# Altium schematic sheet Sheet1.SchDoc
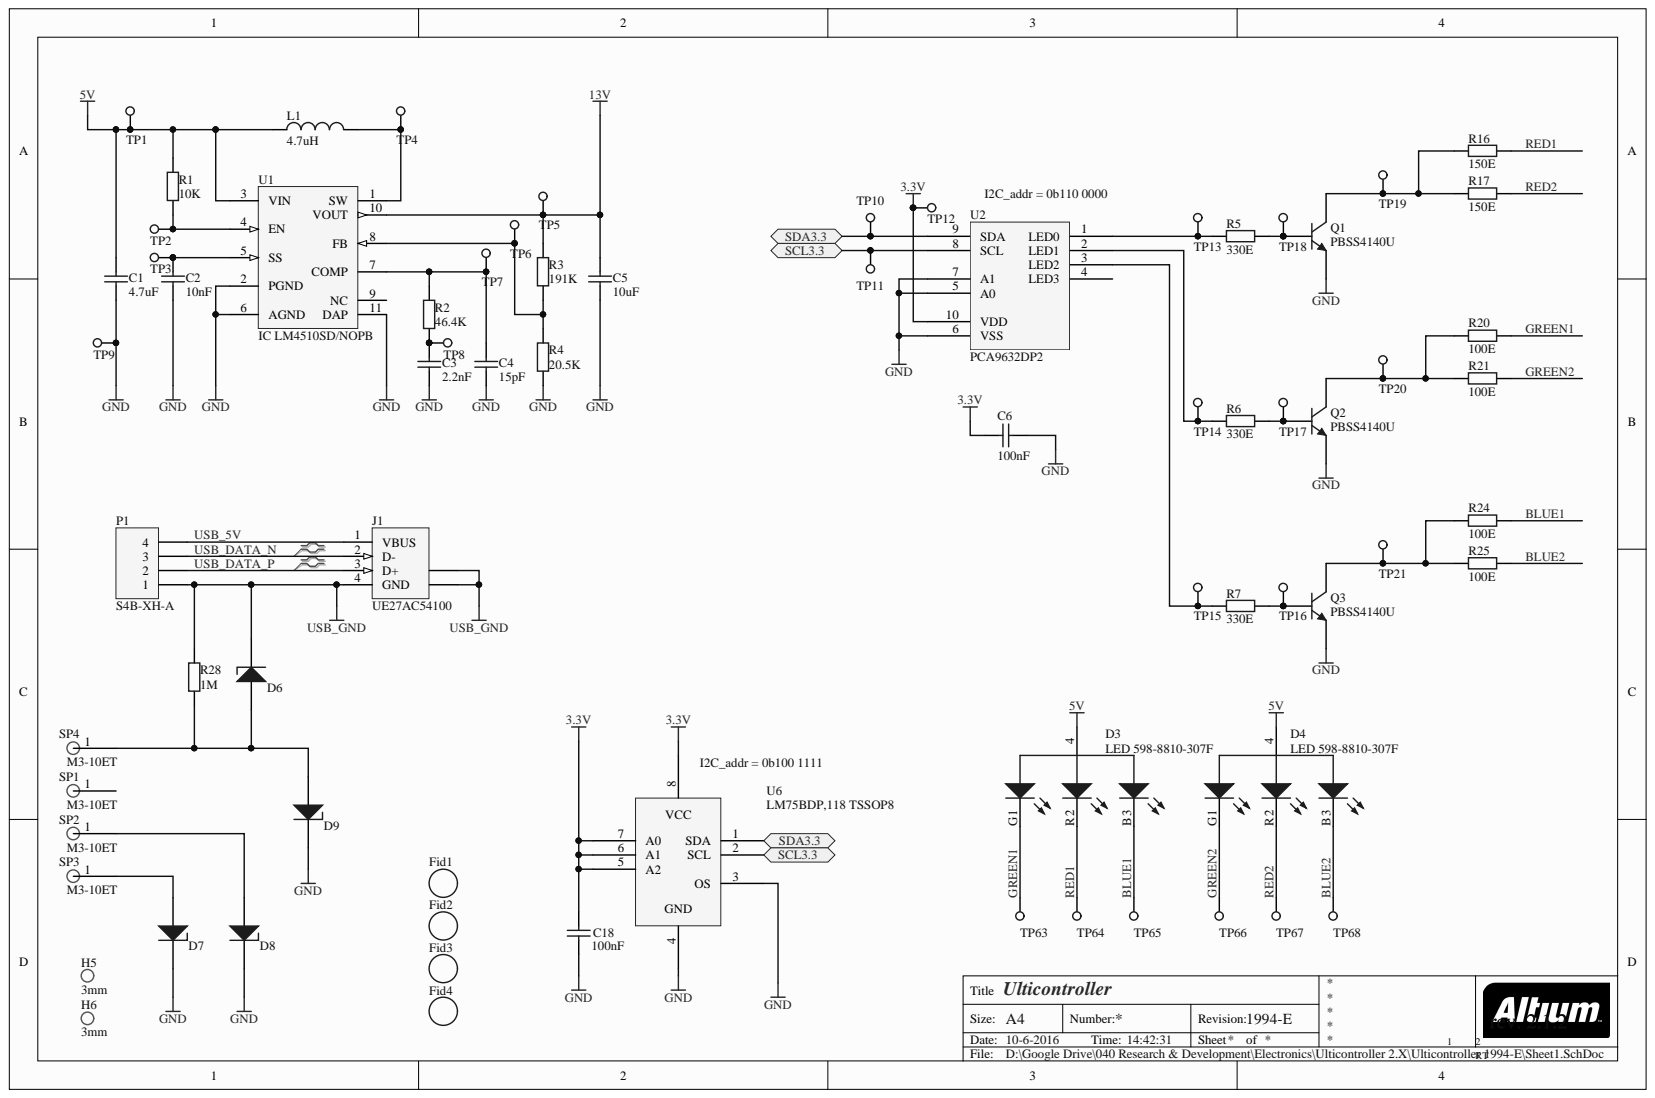
[diagram p0: Sheet1.SchDoc]

## Parts
C1  4.7uF  [C1206 (3216)]
C2  10nF  [C0805 (2012)]
C3  2.2nF  [C0805 (2012)]
C4  15pF  [C0805 (2012)]
C5  10uF  [C1206 (3216)]
C6  100nF  [C0805 (2012)]
C18  100nF  [C0805 (2012)]
D3  LED 598-8810-307F  Mfg code: 598-8810-307F  [LED SMD  598-8810-307F]
D4  LED 598-8810-307F  Mfg code: 598-8810-307F  [LED SMD  598-8810-307F]
D6  ESD9X3.3ST5G  [SODFL100X40-2N]  (value not drawn)
D7  ESD9X3.3ST5G  [SODFL100X40-2N]  (value not drawn)
D8  ESD9X3.3ST5G  [SODFL100X40-2N]  (value not drawn)
D9  ESD9X3.3ST5G  [SODFL100X40-2N]  (value not drawn)
Fid1  Fiducial  [Fiducial 1,5mm]  (value not drawn)  (no BOM)
Fid2  Fiducial  [Fiducial 1,5mm]  (value not drawn)  (no BOM)
Fid3  Fiducial  [Fiducial 1,5mm]  (value not drawn)  (no BOM)
Fid4  Fiducial  [Fiducial 1,5mm]  (value not drawn)  (no BOM)
H5  3mm  [Mounting Hole 3mm PTH]  (no BOM)
H6  3mm  [Mounting Hole 3mm PTH]  (no BOM)
J1  UE27AC54100  [USB A]
L1  4.7uH  [Inductor MCSDC0604]
P1  S4B-XH-A  [S4B-XH-A]
Q1  PBSS4140U  [IC SOT323]
Q2  PBSS4140U  [IC SOT323]
Q3  PBSS4140U  [IC SOT323]
R1  10K  [R0805 (2012)]
R2  46.4K  [R0805 (2012)]
R3  191K  [R0805 (2012)]
R4  20.5K  [R0805 (2012)]
R5  330E  [R0805 (2012)]
R6  330E  [R0805 (2012)]
R7  330E  [R0805 (2012)]
R16  150E  [R0805 (2012)]
R17  150E  [R0805 (2012)]
R20  100E  [R0805 (2012)]
R21  100E  [R0805 (2012)]
R24  100E  [R0805 (2012)]
R25  100E  [R0805 (2012)]
R28  1M  [R0805 (2012)]
SP1  M3-10ET  [SPACER M3-10ET]
SP2  M3-10ET  [SPACER M3-10ET]
SP3  M3-10ET  [SPACER M3-10ET]
SP4  M3-10ET  [SPACER M3-10ET]
TP1  2mm  [TESTPOINT 2mm]  (value not drawn)  (no BOM)
TP2  2mm  [TESTPOINT 2mm]  (value not drawn)  (no BOM)
TP3  2mm  [TESTPOINT 2mm]  (value not drawn)  (no BOM)
TP4  2mm  [TESTPOINT 2mm]  (value not drawn)  (no BOM)
TP5  2mm  [TESTPOINT 2mm]  (value not drawn)  (no BOM)
TP6  2mm  [TESTPOINT 2mm]  (value not drawn)  (no BOM)
TP7  2mm  [TESTPOINT 2mm]  (value not drawn)  (no BOM)
TP8  2mm  [TESTPOINT 2mm]  (value not drawn)  (no BOM)
TP9  2mm  [TESTPOINT 2mm]  (value not drawn)  (no BOM)
TP10  2mm  [TESTPOINT 2mm]  (value not drawn)  (no BOM)
TP11  2mm  [TESTPOINT 2mm]  (value not drawn)  (no BOM)
TP12  2mm  [TESTPOINT 2mm]  (value not drawn)  (no BOM)
TP13  2mm  [TESTPOINT 2mm]  (value not drawn)  (no BOM)
TP14  2mm  [TESTPOINT 2mm]  (value not drawn)  (no BOM)
TP15  2mm  [TESTPOINT 2mm]  (value not drawn)  (no BOM)
TP16  2mm  [TESTPOINT 2mm]  (value not drawn)  (no BOM)
TP17  2mm  [TESTPOINT 2mm]  (value not drawn)  (no BOM)
TP18  2mm  [TESTPOINT 2mm]  (value not drawn)  (no BOM)
TP19  2mm  [TESTPOINT 2mm]  (value not drawn)  (no BOM)
TP20  2mm  [TESTPOINT 2mm]  (value not drawn)  (no BOM)
TP21  2mm  [TESTPOINT 2mm]  (value not drawn)  (no BOM)
TP63  2mm  [TESTPOINT 2mm]  (value not drawn)  (no BOM)
TP64  2mm  [TESTPOINT 2mm]  (value not drawn)  (no BOM)
TP65  2mm  [TESTPOINT 2mm]  (value not drawn)  (no BOM)
TP66  2mm  [TESTPOINT 2mm]  (value not drawn)  (no BOM)
TP67  2mm  [TESTPOINT 2mm]  (value not drawn)  (no BOM)
TP68  2mm  [TESTPOINT 2mm]  (value not drawn)  (no BOM)
U1  IC LM4510SD/NOPB  Mfg code: LM4510SD/NOPB  [IC SDA10A]
U2  PCA9632DP2  [IC TSSOP-10]
U6  LM75BDP,118 TSSOP8  [LM75BDP,118]

## Ports
SCL3.3, SDA3.3

## Nets
3.3V: C6.1 C18.2 TP12.1 U2.10(VDD) U6.5(A2) U6.6(A1) U6.7(A0) U6.8
5V: C1.2 D3.4 D4.4 L1.1 R1.2 TP1.1 U1.3(VIN)
13V: C5.2 R3.2 TP5.1 U1.10(VOUT)
GND: C1.1 C2.1 C3.1 C4.1 C5.1 C6.2 C18.1 D7.1 D8.1 D9.1 Q1.2 Q2.2 Q3.2 R4.1 TP9.1 U1.2(PGND) U1.6(AGND) U1.11(DAP) U2.5(A0) U2.6(VSS) U2.7(A1) U6.3(OS) U6.4
USB_GND: D6.1 J1.4(GND) J1.5 J1.6 P1.1 R28.2
BLUE1: D3.3 R24.2 TP65.1
BLUE2: D4.3 R25.2 TP68.1
GREEN1: D3.1 R20.2 TP63.1
GREEN2: D4.1 R21.2 TP66.1
RED1: D3.2 R16.2 TP64.1
RED2: D4.2 R17.2 TP67.1
SCL3.3: TP11.1 U2.8(SCL) U6.2(SCL) ; port SCL3.3
SDA3.3: TP10.1 U2.9(SDA) U6.1(SDA) ; port SDA3.3
USB_5V: J1.1(VBUS) P1.4
USB_DATA_N: J1.2(D-) P1.3
USB_DATA_P: J1.3(D+) P1.2
NetC2_2: C2.2 TP3.1 U1.5(SS)
NetC3_2: C3.2 R2.1 TP8.1
NetC4_2: C4.2 R2.2 TP7.1 U1.7(COMP)
NetD6_2: D6.2 D9.2 R28.1 SP4.1
NetD7_2: D7.2 SP3.1
NetD8_2: D8.2 SP2.1
NetL1_2: L1.2 TP4.1 U1.1(SW)
NetQ1_1: Q1.1 R5.2 TP18.1
NetQ1_3: Q1.3 R16.1 R17.1 TP19.1
NetQ2_1: Q2.1 R6.2 TP17.1
NetQ2_3: Q2.3 R20.1 R21.1 TP20.1
NetQ3_1: Q3.1 R7.2 TP16.1
NetQ3_3: Q3.3 R24.1 R25.1 TP21.1
NetR1_1: R1.1 TP2.1 U1.4(EN)
NetR3_1: R3.1 R4.2 TP6.1 U1.8(FB)
NetR5_1: R5.1 TP13.1 U2.1(LED0)
NetR6_1: R6.1 TP14.1 U2.2(LED1)
NetR7_1: R7.1 TP15.1 U2.3(LED2)

Not connected: SP1 1; U1 9(NC); U2 4(LED3)

## Library data of the parts
C1 = Cap 4.7uF X7R 1206: Cap 4.7uF 1206 X7R 10% 25V; Mfg: TDK; Mfg code: C3216X7R1E475K160AC; Component Kind: Standard; Faber ItemCode: -; Max soldering temp: -; Remarks: -; SMD: Yes; Supplier: Farnell; Supplier code: 1907356; Value: 4.7uF; Library Name: Faber_SCH_lib.SchLib
C2 = Cap 10nF X7R 0805: Cap 10nF X7R 0805 10% 100V; Mfg: AVX; Mfg code: 08051C103K4Z2A; Component Kind: Standard; Faber ItemCode: -; Max soldering temp: -; Remarks: -; SMD: Yes; Supplier: Farnell; Supplier code: 1833850; Value: 10nF; Library Name: Faber_SCH_lib.SchLib
C3 = Cap 2.2nF X7R 0805: Cap 2.2nF X7R 0805 10% 100V; Mfg: KEMET; Mfg code: C0805F222K1RACAUTO; Component Kind: Standard; Faber ItemCode: -; Max soldering temp: -; Remarks: -; SMD: Yes; Supplier: Farnell; Supplier code: 1869056; Value: 2.2nF; Library Name: Faber_SCH_lib.SchLib
C4 = Cap 15pF NPO 0805: Cap 15pF NPO 0805 5% 100V; Mfg: AVX; Mfg code: 08051A150JAT2A; Component Kind: Standard; Faber ItemCode: -; Max soldering temp: -; Remarks: -; SMD: Yes; Supplier: Farnell; Supplier code: 1658873; Value: 15pF; Library Name: Faber_SCH_lib.SchLib
C5 = Cap 10uF X7R 1206: Cap 10uF 1206 X7R 10% 25V; Mfg: KEMET; Mfg code: C1206C106K3RAC TU; Component Kind: Standard; Faber ItemCode: -; Max soldering temp: -; Remarks: -; SMD: Yes; Supplier: Farnell; Supplier code: 2118134; Value: 10uF; Library Name: Faber_SCH_lib.SchLib
C6 C18 = Cap 100nF X7R 0805: Cap 100nF X7R 0805 10% 100V; Mfg: AVX; Mfg code: 08051C104K4T2A; Component Kind: Standard; Faber ItemCode: -; Max soldering temp: -; Remarks: -; SMD: Yes; Supplier: Farnell; Supplier code: 1833888; Value: 100nF; Library Name: Faber_SCH_lib.SchLib
D3 D4 = LED 598-8810-307F: LED MULTICOLOR RED/GRN/BLUE 1208; Bestel grote: 1; Mfg: DIALIGHT; Prijs: 2.26; Component Kind: Standard; Faber Artikelomschrijving: -; Faber itemcode: -; Max soldering temp: -; Remarks: -; SMD: Yes; Supplier: Farnell; Supplier code: 1850760; Value: -; Library Name: Faber_SCH_lib.SchLib
D6-D9 = ESD9X3.3ST5G: TVS DIODE 3.3VWM 10.4VC SOD923; Capacitance @ Frequency: 80pF @ 1MHz; Current - Peak Pulse (10/1000µs): 9.8A (8/20µs); Mfg: ON Semiconductor; Power - Peak Pulse: 102W; Power Line Protection: No; Type: Zener; Unidirectional Channels: 1; Voltage - Breakdown (Min): 5V; Voltage - Clamping (Max) @ Ipp: 10.4V; Voltage - Reverse Standoff (Typ): 3.3V (Max); Applications: Automotive; Bidirectional Channels: -; Category: Circuit Protection; Component Kind: Standard; ComponentLink1Description: http://www.onsemi.com/pub_link/Collateral/ESD9X3.3ST5G-D.PDF; ComponentLink1URL: http://www.onsemi.com/pub_link/Collateral/ESD9X3.3ST5G-D.PDF; Mfg code: ESD9X3.3ST5G; Mounting Type: Surface Mount; Operating Temperature: -55°C ~ 150°C (TJ); Package / Case: SOD-923; Packaging: Tape & Reel (TR); Pricing: 8000=0.03287, 16000=0.02794, 24000=0.02629, 56000=0.02465, 200000=0.02136 (EUR); RoHS: RoHS Compliant; Stock: 136000; Supplier 1: Digi-Key; Supplier Device Package: SOD-923; Supplier Part Number 1: ESD9X3.3ST5GOSTR-ND; Library Name: Olimex interface board.SCHLIB
Fid1-Fid4 = (no symbol name): Remarks: Not a component; Description: Fiducial; Faber ItemCode: -; Max soldering temp: -; Mfg: -; Mfg code: -; Supplier: -; Supplier code: -; Value: -; Library Name: Faber_SCH_lib.SchLib
H5 H6 = (no symbol name): Mounting Hole 3mm PTH; Remarks: Not a component; Faber ItemCode: -; Max soldering temp: -; Mfg: -; Mfg code: -; Supplier: -; Supplier code: -; Value: -; Library Name: Faber_SCH_lib.SchLib
J1 = UE27AC54100: CONN RCPT USB TYPE A R/A GOLD; Manufacturer: Amphenol Commercial Products; Connector Type: USB - A; Gender: Receptacle; Number of Contacts: 4; Specifications: USB 2.0; Category: Connectors, Interconnects; Component Kind: Standard; ComponentLink1Description: http://www.amphenolcanada.com/ProductSearch/drawings/AC/UE27ACX4X0X.pdf; ComponentLink1URL: http://www.amphenolcanada.com/ProductSearch/drawings/AC/UE27ACX4X0X.pdf; Features: Board Guide; Ingress Protection: -; Manufacturer Part Number: UE27AC54100; Mfg: Amphenol Commercial Products; Mfg code: UE27AC54100; Mounting Type: Through Hole, Right Angle, Horizontal; Packaging: Bulk; Pricing 1: 1=0.72, 10=0.506, 50=0.3902, 100=0.3396, 250=0.289, 500=0.27454, 1000=0.24564, 2500=0.19507, 5000=0.19218 (EUR); RoHS: RoHS Compliant; Signal Integrity: USB1.1; Stock 1: 46; Supplier 1: Digi-Key; Supplier Part Number 1: UE27AC54100-ND; Library Name: Marlin.SchLib
L1 = Inductor 4.7uH SMD: Mfg: MULTICOMP; Mfg code: MCSDC0604-4R7MU; Component Kind: Standard; Description: Inductor 4.7uH SMD; Faber ItemCode: -; Max soldering temp: -; Remarks: -; SMD: Yes; Supplier: Farnell; Supplier code: 1864164RL; Value: 4.7uH; Library Name: Faber_SCH_lib.SchLib
P1 = S4B-XH-A: CONN HEADER XH SIDE 4POS 2.5MM; Manufacturer: JST Sales America Inc; Manufacturer Part Number: S4B-XH-A(LF)(SN); Connector Type: Header, Shrouded; Mfg: JST; Number of Positions: 4; Number of Positions Loaded: All; Pitch: 0.098" (2.50mm); Category: Connectors, Interconnects; Color: Natural; Component Kind: Standard; ComponentLink1Description: http://www.jst-mfg.com/product/pdf/eng/eXH.pdf; ComponentLink1URL: http://www.jst-mfg.com/product/pdf/eng/eXH.pdf; Contact Finish: Tin; Contact Finish Thickness: -; Contact Mating Length: -; Contact Type: Male Pin; Fastening Type: -; Features: -; Mfg code: S4B-XH-A(LF)(SN); Mounting Type: Through Hole, Right Angle; Number of Rows: 1; Packaging: Bulk; Pricing 1: 1=0.22, 10=0.212, 50=0.1582, 100=0.1469, 250=0.12992, 500=0.12428, 1000=0.10169, 2500=0.09321 (EUR); RoHS: RoHS Compliant; Row Spacing: -; Signal Integrity: Connector; Stock 1: 27147; Supplier 1: Digi-Key; Supplier Part Number 1: 455-2243-ND; Termination: Press-Fit; Library Name: Marlin.SchLib
Q1-Q3 = NPN PBSS4140U: NPN PBSS4140U SOT-323 1A; Mfg: NXP; Component Kind: Standard; Faber ItemCode: -; Max soldering temp: -; Mfg code: PBSS4140U; Remarks: -; SMD: Yes; Supplier: Farnell; Supplier code: 8736197; Value: -; Library Name: Faber_SCH_lib.SchLib
R1 = Res 10K 0805: Res 10K 0805 1% 100mW; Mfg: MULTICOMP; Mfg code: MC 0.1W 0805 1% 10K; Component Kind: Standard; Faber ItemCode: -; Max soldering temp: -; Remarks: -; SMD: Yes; Supplier: Farnell; Supplier code: 9332391; Value: 10K; Library Name: Faber_SCH_lib.SchLib
R2 = Res 46.4K 0805: Res 46.4K 0805 1% 100mW; Mfg: MULTICOMP; Mfg code: MC0.1W08051%46K4; Component Kind: Standard; Faber ItemCode: -; Max soldering temp: -; Remarks: -; SMD: Yes; Supplier: Farnell; Supplier code: 2141881RL; Value: 46.4K; Library Name: Faber_SCH_lib.SchLib
R3 = Res 191K 0805: Res 191K 0805 1% 125mW; Mfg: VISHAY DRALORIC; Mfg code: CRCW0805191KFKEA; Component Kind: Standard; Faber ItemCode: -; Max soldering temp: -; Remarks: -; SMD: Yes; Supplier: Farnell; Supplier code: 2139049; Value: 191K; Library Name: Faber_SCH_lib.SchLib
R4 = Res 20.5K 0805: Res 20.5K 0805 1% 100mW; Mfg: MULTICOMP; Mfg code: MC0.1W08051%20K5; Component Kind: Standard; Faber ItemCode: -; Max soldering temp: -; Remarks: -; SMD: Yes; Supplier: Farnell; Supplier code: 2141862RL; Value: 20.5K; Library Name: Faber_SCH_lib.SchLib
R5-R7 = Res 330E 0805: Res 330E 0805 1% 100mW; Mfg: MULTICOMP; Mfg code: MC 0.1W 0805 1% 330R; Component Kind: Standard; Faber ItemCode: -; Max soldering temp: -; Remarks: -; SMD: Yes; Supplier: Farnell; Supplier code: 9333037; Value: 330E; Library Name: Faber_SCH_lib.SchLib
R16 R17 = Res 150E 0805: Res 150E 0805 1% 125mW; Bestel grote: 5000; Faber Artikelomschrijving: RES 150E 1% 0,125W 0805; Faber ItemCode: 31224600; Mfg: YAGEO; Mfg code: 2322 734 61501; Prijs: 0,0012; Component Kind: Standard; Library Name: Faber_SCH_lib.SchLib; Max soldering temp: -; Remarks: -; SMD: Yes; Supplier: AVNET Abacus; Supplier code: 2322 734 61501; Value: 150E
R20 R21 R24 R25 = Res 100E 0805: Res 100E 0805 1% 125mW; Bestel grote: 5000; Faber Artikelomschrijving: RES 100E 1% 0,125W 0805; Faber ItemCode: 31560100; Mfg: YAGEO; Mfg code: WRC31022/ RC0805FR-07100RL; Prijs: 0,0009; Component Kind: Standard; Library Name: Faber_SCH_lib.SchLib; Max soldering temp: -; Remarks: -; SMD: Yes; Supplier: Rutronik; Supplier code: WRC31022/ RC0805FR-07100RL; Value: 100E
R28 = Res 1M 0805: Res 1M 0805 1% 100mW; Mfg: MULTICOMP; Mfg code: MC 0.1W 0805 1% 1M; Component Kind: Standard; Faber ItemCode: -; Max soldering temp: -; Remarks: -; SMD: Yes; Supplier: Farnell; Supplier code: 9332413; Value: 1M; Library Name: Faber_SCH_lib.SchLib
SP1-SP4 = (no symbol name): Spacer SMTSO-M3-10ET; Bestel grote: 300; Faber Artikelomschrijving: MOER-SMD SMTSO-M3-10ET  PEM; Faber ItemCode: 36462900; Mfg: PEM; Prijs: 0.4311; Component Kind: Standard; Max soldering temp: -; Mfg code: SMTSO-M3-10ET; Remarks: -; SMD: Yes; Supplier: Onkenhout & Onkenhout; Supplier code: SMTSO-M3-10ET; Value: -; Library Name: UltiController.SCHLIB
TP1-TP21 TP63-TP68 = (no symbol name): Testpoint, 2mm, round; Remarks: Not a component; Faber ItemCode: -; Max soldering temp: -; Mfg: -; Mfg code: -; Supplier: -; Supplier code: -; Value: -; Library Name: Faber_SCH_lib.SchLib
U1 = IC LM4510SD/NOPB: IC Synchronous Step-Up DC/DC Converter with True Shutdown Isolation, 10-pin LLP, Pb-Free; Bestel grote: 1; Faber Artikelomschrijving: IC LM4510SD/NOPB LLP-10 TI; Faber ItemCode: 36966700; Mfg: TEXAS INSTRUMENTS; Prijs: 1,10; Component Kind: Standard; Library Name: Faber_SCH_lib.SchLib; Max soldering temp: -; Remarks: -; SMD: Yes; Supplier: Digikey; Supplier code: LM4510SD/NOPBDKR-ND; Value: -
U2 = IC PCA9632: IC LED DRIVER RGBA; Bestel grote: 1000; Faber Artikelomschrijving: IC PCA9632DP2 TSSOP-10 NXP; Faber ItemCode: 36966800; Mfg: NXP; Prijs: 1,0876; Component Kind: Standard; Library Name: Faber_SCH_lib.SchLib; Max soldering temp: -; Mfg code: PCA9632DP2; Remarks: -; SMD: Yes; Supplier: Digikey; Supplier code: 568-8254-6-ND; Value: -
U6 = LM75BDP: i2c temperature sensor; Mfg: NXP; Component Kind: Standard; Mfg code: LM75BDP,118; Supplier 1: Farnell; Supplier Part Number 1: 2396742; Library Name: UltiController.SCHLIB
